# Altium schematic sheet ChargeBlock.SchDoc
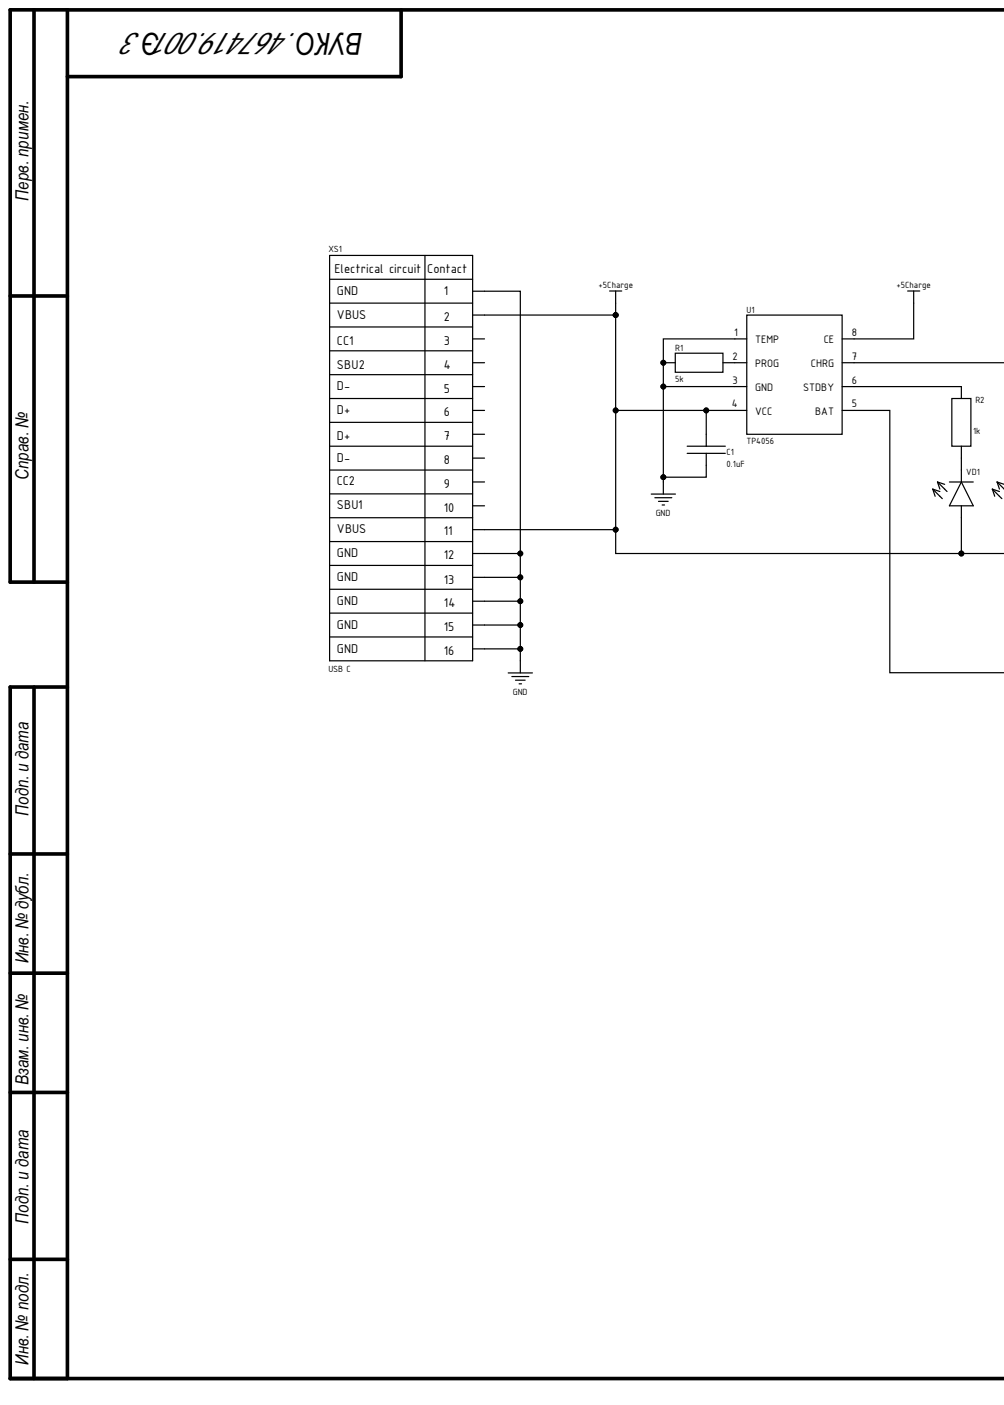
[diagram p0: ChargeBlock.SchDoc, left]
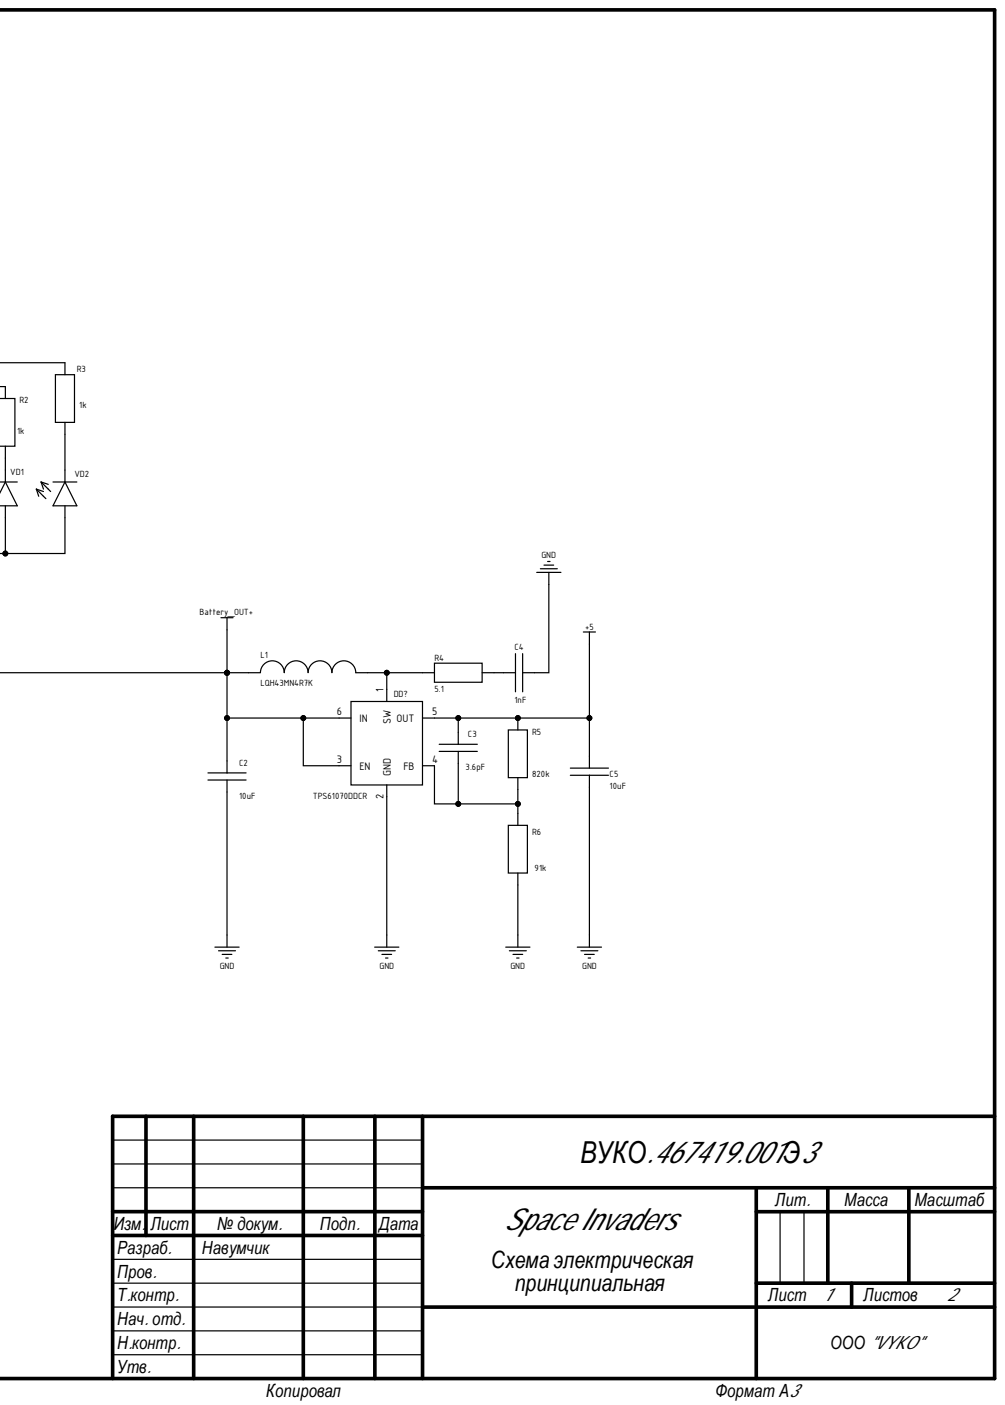
[diagram p1: ChargeBlock.SchDoc, right]

## Parts
C1  0.1uF  [Cap 0603]
C2  10uF  [Cap 0603]
C3  3.6pF  [Cap 0603]
C4  1nF  [Cap 0603]
C5  10uF  [Cap 0603]
DD?  TPS61070DDCR  [SOT23-6]
L1  LQH43MN4R7K  [LQH43M]
R1  5k  [Resistor 0603]
R2  1k  [Resistor 0603]
R3  1k  [Resistor 0603]
R4  5.1  [Resistor 0603]
R5  820k  [Resistor 0603]
R6  91k  [Resistor 0603]
U1  TP4056  [SOP8]
VD1  LED 0603  [VD0603]  (value not drawn)
VD2  LED 0603  [VD0603]  (value not drawn)
XS1  USB C  [USB C 16]

## Nets
+5: C3.2 C5.1 DD?.5(OUT) R5.1
+5Charge: C1.2 U1.4(VCC) U1.8(CE) VD1.1 VD2.1 XS1.2 XS1.11
Battery_OUT+: C2.2 DD?.3(EN) DD?.6(IN) L1.1 U1.5(BAT)
GND: C1.1 C2.1 C4.2 C5.2 DD?.2(GND) R1.1 R6.2 U1.1(TEMP) U1.3(GND) XS1.1 XS1.12 XS1.13 XS1.14 XS1.15 XS1.16
NetC3_1: C3.1 DD?.4(FB) R5.2 R6.1
NetC4_1: C4.1 R4.2
NetDD?_1: DD?.1(SW) L1.2 R4.1
NetR1_2: R1.2 U1.2(PROG)
NetR2_1: R2.1 U1.6(STDBY)
NetR2_2: R2.2 VD1.2
NetR3_1: R3.1 U1.7(CHRG)
NetR3_2: R3.2 VD2.2

Not connected: XS1 3 4 5 6 7 8 9 10

## Library data of the parts
C1-C5 = Capacitor: Component Kind: Standard; Library Name: Schematic.SchLib
L1 = Inductor: Component Kind: Standard; Library Name: Schematic.SchLib
R1-R6 = Resistor: SMD 0402-0805; Component Kind: Standard
  R1-R3: Library Name: Schlib1.SchLib
  R4-R6: Library Name: Schematic.SchLib
XS1 = USB C 16: Component Kind: Standard; Library Name: Schematic.SchLib
DD? = TPS61070DDCR: Component Kind: Standard; Library Name: Schematic.SchLib
U1 = TP4056: Component Kind: Standard; Library Name: Schlib1.SchLib
VD1 VD2 = LED 0603: Component Kind: Standard; Library Name: Schlib1.SchLib
